# Altium schematic sheet 30to50V-24V_10A.SchDoc
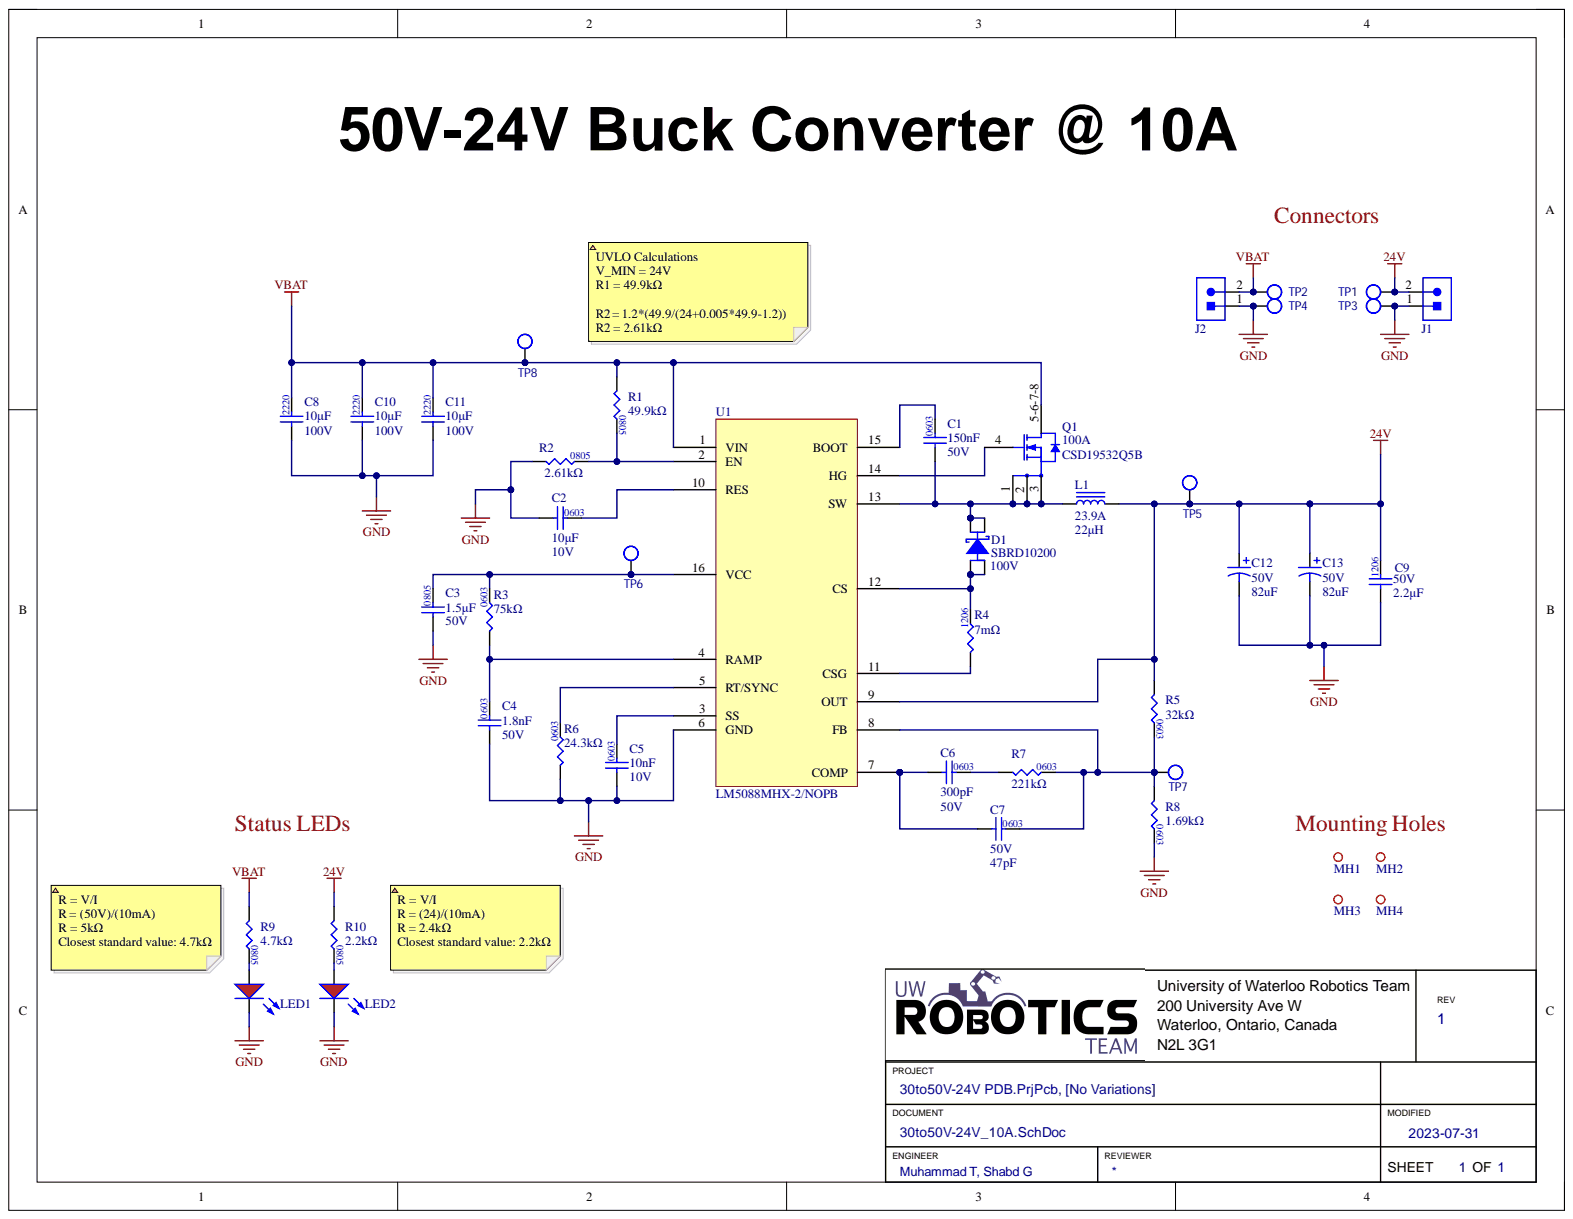
[diagram p0: 30to50V-24V_10A.SchDoc]

## Parts
C1  value 150nF  Voltage Rating: 50V  [CAP0603]  (hidden comment: CAP_150nF_50V_0603)
C2  value 10μF  Voltage Rating: 10V  [CAP0603]  (hidden comment: CAP_10uF_10V_0603)
C3  value 1.5μF  Voltage Rating: 50V  [CAP0805]  (hidden comment: CAP_1.5uF_50V_0805)
C4  value 1.8nF  Voltage Rating: 50V  [CAP0603]  (hidden comment: CAP_1.8nF_50V_0603)
C5  value 10nF  Voltage Rating: 10V  [CAP0603]  (hidden comment: CAP_10nF_25V_0603)
C6  value 300pF  Voltage Rating: 50V  [CAP0603]  (hidden comment: CAP_300pF_10V_0603)
C7  value 47pF  Package/Footprint: 0603  Voltage Rating: 50V  [CAP0603]  (hidden comment: CAP_47pF_50V_0603)
C8  value 10μF  Voltage Rating: 100V  [C5750X7S2A106M230KB]  (hidden comment: CAP_10uF_50V_2220)
C9  value 2.2μF  Voltage Rating: 50V  [CAP1206]  (hidden comment: CAP_2.2uF_50V_1206)
C10  value 10μF  Voltage Rating: 100V  [C5750X7S2A106M230KB]  (hidden comment: CAP_10uF_50V_2220)
C11  value 10μF  Voltage Rating: 100V  [C5750X7S2A106M230KB]  (hidden comment: CAP_10uF_50V_2220)
C12  Capacitance: 82uF  Voltage Rating: 50V  [RADIAL_CORNELL_D]  (hidden comment: CAP_47uF_100V_ALUM)
C13  Capacitance: 82uF  Voltage Rating: 50V  [RADIAL_CORNELL_D]  (hidden comment: CAP_47uF_100V_ALUM)
D1  Manufacturer Part Number 1: SBRD10200  Vr (Max): 100V  [D-PAK]  (hidden comment: DIO_SCHOTTKY_100V_5.5A)
J1  CON_2POS_SCREW_TERM  [1935161]  (value not drawn)
J2  CON_2POS_SCREW_TERM  [1935161]  (value not drawn)
L1  Current Rating: 23.9A  Inductance: 22μH  [74437529203220]  (hidden comment: IND_22uH_23.9A)
LED1  Package/Footprint: 0805  [LED_0805_RED]  (hidden comment: LED_RED)
LED2  Package/Footprint: 0805  [LED_0805_RED]  (hidden comment: LED_RED)
MH1  MOUNTING_HOLE_M3_GIMBAL_REV2  [MOUNTING_HOLE_3mm_GIMBAL_REV2]  (value not drawn)  (no BOM)
MH2  MOUNTING_HOLE_M3_GIMBAL_REV2  [MOUNTING_HOLE_3mm_GIMBAL_REV2]  (value not drawn)  (no BOM)
MH3  MOUNTING_HOLE_M3_GIMBAL_REV2  [MOUNTING_HOLE_3mm_GIMBAL_REV2]  (value not drawn)  (no BOM)
MH4  MOUNTING_HOLE_M3_GIMBAL_REV2  [MOUNTING_HOLE_3mm_GIMBAL_REV2]  (value not drawn)  (no BOM)
Q1  Id: 100A  Manufacturer Part Number 1: CSD19532Q5B  [SSM6K819R,LF]  (hidden comment: NMOS_100V_100A)
R1  value 49.9kΩ  Package/Footprint: 0805  [RES0805]  (hidden comment: RES_49.9kΩ_0805_1%)
R2  value 2.61kΩ  Package/Footprint: 0805  [RES0805]  (hidden comment: RES_2.61kΩ_0805_1%)
R3  value 75kΩ  [RES0603]  (hidden comment: RES_75kΩ_0603_0.5%)
R4  value 7mΩ  Package/Footprint: 1206  [RES1206]  (hidden comment: RES_0.007Ω_1206_1%)
R5  value 32kΩ  Package: 0603  [RES0603]  (hidden comment: RES_32KΩ_0603_0.1%)
R6  value 24.3kΩ  Package: 0603  [RES0603]  (hidden comment: RES_24.3kΩ_0603_1%)
R7  value 221kΩ  [RES0603]  (hidden comment: RES_221kΩ_0603_1%)
R8  value 1.69kΩ  [RES0603]  (hidden comment: RES_1.69kΩ_0603_1%)
R9  value 4.7kΩ  Package: 0805  [RES0805]  (hidden comment: RES_4.7kΩ_0805_5%)
R10  value 2.2kΩ  Package: 0805  [RES0805]  (hidden comment: RES_2.2kΩ_0805_5%)
TP1  TESTPOINT_LOOP  [TESTPOINT_LOOP]  (value not drawn)
TP2  TESTPOINT_LOOP  [TESTPOINT_LOOP]  (value not drawn)
TP3  TESTPOINT_LOOP  [TESTPOINT_LOOP]  (value not drawn)
TP4  TESTPOINT_LOOP  [TESTPOINT_LOOP]  (value not drawn)
TP5  TESTPOINT_LOOP  [TESTPOINT_LOOP]  (value not drawn)
TP6  TESTPOINT_LOOP  [TESTPOINT_LOOP]  (value not drawn)
TP7  TESTPOINT_LOOP  [TESTPOINT_LOOP]  (value not drawn)
TP8  TESTPOINT_LOOP  [TESTPOINT_LOOP]  (value not drawn)
U1  LM5088  [LM5088]

## Nets
24V: C9.2 C12.1 C13.1 J1.2 L1.2 R5.1 R10.1 TP1.1 TP5.1 U1.9(OUT)
GND: C2.1 C3.1 C4.1 C5.1 C8.1 C9.1 C10.1 C11.1 C12.2 C13.2 J1.1 J2.1 LED1.2 LED2.2 R2.1 R6.1 R8.2 TP3.1 TP4.1 U1.6(GND)
VBAT: C8.2 C10.2 C11.2 J2.2 Q1.5-6-7-8 R1.1 R9.1 TP2.1 TP8.1 U1.1(VIN)
NetC1_1: C1.1 D1.2 D1.4 L1.1 Q1.1 Q1.2 Q1.3 U1.13(SW)
NetC1_2: C1.2 U1.15(BOOT)
NetC2_2: C2.2 U1.10(RES)
NetC3_2: C3.2 R3.2 TP6.1 U1.16(VCC)
NetC4_2: C4.2 R3.1 U1.4(RAMP)
NetC5_2: C5.2 U1.3(SS)
NetC6_1: C6.1 C7.1 U1.7(COMP)
NetC6_2: C6.2 R7.1
NetC7_2: C7.2 R5.2 R7.2 R8.1 TP7.1 U1.8(FB)
NetD1_1: D1.1 D1.3 R4.2 U1.12(CS)
NetLED1_1: LED1.1 R9.2
NetLED2_1: LED2.1 R10.2
NetQ1_4: Q1.4 U1.14(HG)
NetR1_2: R1.2 R2.2 U1.2(EN)
NetR4_1: R4.1 U1.11(CSG)
NetR6_2: R6.2 U1.5(RT/SYNC)

## Library data of the parts
C1 = CAP_150nF_50V_0603: CAP CER 0.15UF 50V X7R 0603; Manufacturer 1: Yageo; Manufacturer Part Number 1: CC0603KRX7R9BB154; Component Kind: Standard; Dielectric: X7R; Supplier 1: Digi-Key; Supplier Part Number 1: 13-CC0603KRX7R9BB154CT-ND; Library Name: UWRT_Schematic_Library_2020.SchLib
C2 = CAP_10uF_10V_0603: CAP CER 10UF 10V X5R 0603; Manufacturer 1: Yageo; Manufacturer Part Number 1: CC0603MRX5R6BB106; Component Kind: Standard; Dielectric: X5R; Supplier 1: Digi-Key; Supplier Part Number 1: 311-1817-1-ND; Library Name: UWRT_Schematic_Library_2020.SchLib
C3 = CAP_1.5uF_50V_0805: CAP CER 1800PF 50V C0G/NP0 0603; Manufacturer 1: TDK Corporation; Manufacturer Part Number 1: C2012X7R1H155K125AC; Dielectric: X7R; Component Kind: Standard; Supplier 1: Digi-Key; Supplier Part Number 1: C2012X7R1H155K125AC; Library Name: UWRT_Schematic_Library_2020.SchLib
C4 = CAP_1.8nF_50V_0603: CAP CER 1800PF 50V C0G/NP0 0603; Manufacturer 1: Murata Electronics; Manufacturer Part Number 1: GRM1885C1H182JA01J; Dielectric: C0G; Component Kind: Standard; Supplier 1: Digi-Key; Supplier Part Number 1: 490-6380-1-ND; Library Name: UWRT_Schematic_Library_2020.SchLib
C5 = CAP_10nF_25V_0603: CAP CER 10000PF 25V C0G 0603; Manufacturer 1: Yageo; Manufacturer Part Number 1: C1608C0G1E103J080AA; Component Kind: Standard; Dielectric: C0G; Supplier 1: Digi-Key; Supplier Part Number 1: 445-2664-1-ND; Library Name: UWRT_Schematic_Library_2020.SchLib
C6 = CAP_300pF_10V_0603: CAP CER 300PF 50V NP0 0603; Manufacturer 1: KYOCERA AVX; Manufacturer Part Number 1: 06035A301JAT2A; Component Kind: Standard; Dielectric: NP0; Supplier 1: Digi-Key; Supplier Part Number 1: 478-10311-1-ND; Library Name: UWRT_Schematic_Library_2020.SchLib
C7 = CAP_47pF_50V_0603: CAP CER 47PF 50V C0G/NP0 0603; Manufacturer 1: Samsung Electro-Mechanics; Manufacturer Part Number 1: CL10C470JB8NNNC; Dielectric: C0G; Component Kind: Standard; Mounting Type: SMD; Supplier 1: Digi-Key; Supplier Part Number 1: 1276-1037-1-ND; Library Name: UWRT_Schematic_Library_2020.SchLib
C8 C10 C11 = CAP_10uF_50V_2220: CAP CER 10UF 100V X7S 2220; Manufacturer 1: TDK Corporation; Manufacturer Part Number 1: C5750X7S2A106K230KB; Component Kind: Standard; Dielectric: X7S; Supplier 1: Digi-Key; Supplier Part Number 1: 445-13408-1-ND; Library Name: UWRT_Schematic_Library_2020.SchLib
C9 = CAP_2.2uF_50V_1206: CAP CER 2.2UF 50V X7R 1206; Manufacturer 1: Murata Electronics; Manufacturer Part Number 1: GCM31CR71H225KA55L; Component Kind: Standard; Dielectric: X7R; Supplier 1: Digi-Key; Supplier Part Number 1: 490-4796-1-ND; Library Name: UWRT_Schematic_Library_2020.SchLib
C12 C13 = CAP_47uF_100V_ALUM: Aluminum Electrolytic Capacitor, Polarized, Aluminum (wet), 100V, 20% +Tol, 20% -Tol, 47uF, Surface Mount, 5454; Manufacturer: Nichicon; Manufacturer Part Number: UCD2A470MNQ1MS; Capacitor Type: Aluminium; Leakage Current: 47uA; Ripple Current: 250mA; Tan Delta: 0.07; Category: Aluminum Electrolytic Capacitors; Component Kind: Standard; ComponentLink1Description: https://datasheet.ciiva.com:443/pdfs/VipMasterIC/IC/NICH/NICH-S-A0003527725/NICH-S-A0003527725-1.pdf?src-supplier=IHS+Markit; ComponentLink1URL: https://datasheet.ciiva.com:443/pdfs/VipMasterIC/IC/NICH/NICH-S-A0003527725/NICH-S-A0003527725-1.pdf?src-supplier=IHS+Markit; ComponentLink2Description: https://datasheet.ciiva.com/11776/0900766b80ebdadd-11776792.pdf?src-supplier=RSComponents; ComponentLink2URL: https://datasheet.ciiva.com/11776/0900766b80ebdadd-11776792.pdf?src-supplier=RSComponents; ComponentLink3Description: https://datasheet.ciiva.com/30526/getdatasheetpartid-513218-30526979.pdf?src-supplier=Verical; ComponentLink3URL: https://datasheet.ciiva.com/30526/getdatasheetpartid-513218-30526979.pdf?src-supplier=Verical; ComponentLink4Description: https://datasheet.ciiva.com/16389/e-cd-16389182.pdf?src-supplier=Future+Electronics; ComponentLink4URL: https://datasheet.ciiva.com/16389/e-cd-16389182.pdf?src-supplier=Future+Electronics; ComponentLink5Description: https://datasheet.ciiva.com/17523/e-cd-17523974.pdf?src-supplier=Avnet+Europe; ComponentLink5URL: https://datasheet.ciiva.com/17523/e-cd-17523974.pdf?src-supplier=Avnet+Europe; ComponentLink6Description: https://datasheet.ciiva.com/6326/e-cd-6326630.pdf?src-supplier=Digi-Key; ComponentLink6URL: https://datasheet.ciiva.com/6326/e-cd-6326630.pdf?src-supplier=Digi-Key; ComponentLink7Description: https://datasheet.ciiva.com/6326/e-cd-6326632.pdf?src-supplier=Digi-Key; ComponentLink7URL: https://datasheet.ciiva.com/6326/e-cd-6326632.pdf?src-supplier=Digi-Key; ComponentLink8Description: https://datasheet.ciiva.com/6326/e-cd-6326634.pdf?src-supplier=Digi-Key; ComponentLink8URL: https://datasheet.ciiva.com/6326/e-cd-6326634.pdf?src-supplier=Digi-Key; Dielectric Material: Aluminium; Height: 13.5mm; JESD-609 Code: e3; Length: 14.6mm; Max Operating Temperature: 105°C; Min Operating Temperature: -55°C; Mount: Surface Mount; Negative Tolerance: 20%; Number of Pins: 2; Package Shape: Cylindrical; Package Style: SMTMeter; Packaging: Tape and Reel; Polarity: Polarized; Positive Tolerance: 20%; Pricing 1: 1=2.15000, 10=1.69800, 50=1.47140, 100=1.27330 (USD); Rated DC Voltage (URdc): 100V; REACH SVHC: Yes; RoHS: Yes; RoHS Compliant: Yes; Size Code: 7575; Stock 1: 515; Supplier 1: Digi-Key; Supplier Part Number 1: 493-6201-1-ND; Terminal Finish: Copper; Termination: Flat; Width: 13.6mm; Library Name: UWRT_Schematic_Library_2020.SchLib
D1 = DIO_SCHOTTKY_200V_10A: DIODE SCHOTTKY 200V 10A DPAK; Manufacturer 1: SMC Diode Solutions; Package/Footprint: TO-252-3, DPak (2 Leads + Tab), SC-63; Component Kind: Standard; Mounting Type: SMD; Supplier 1: Digi-Key; Supplier Part Number 1: 1655-SBRD10200CT-ND; Library Name: UWRT_Schematic_Library_2020.SchLib
J1 J2 = CON_2POS_SCREW_TERM: TERM BLK 2POS SIDE ENTRY 5MM; Manufacturer 1: Phoenix Contact; Package/Footprint: SMD; Component Kind: Standard; Manufacturer Part Number 1: 1935161; Mounting Type: TH; Supplier 1: Digi-Key; Supplier Part Number 1: 277-1667-ND; Library Name: UWRT_Schematic_Library_2020.SchLib
L1 = IND_22uH_23.9A: FIXED IND 22UH 30A 2.4 MOHM SMD; Manufacturer 1: Würth Elektronik; DC Resistance (DCR): 4.9mR; Inductance Tolerance: 20%; Component Kind: Standard; Manufacturer Part Number 1: 74437529203220; Mounting Type: SMD; Saturation Current: 23.9A; Supplier 1: Digi-Key; Supplier Part Number 1: 732-11711-ND; Library Name: UWRT_Schematic_Library_2020.SchLib
LED1 LED2 = LED_RED: LED RED DIFFUSED 0805 SMD; Manufacturer 1: OSRAM; Manufacturer Part Number 1: LS R976-NR-1; Forward Voltage Drop: 2V; Component Kind: Standard; Mounting Type: SMD; Supplier 1: Digi-Key; Supplier Part Number 1: 475-1278-1-ND; Library Name: UWRT_Schematic_Library_2020.SchLib
MH1-MH4 = MOUNTING_HOLE_M3_GIMBAL_REV2: MOUNTING_HOLE_M3 Gimbal Rev2; Library Name: UWRT_Schematic_Library_2020.SchLib
Q1 = NMOS_100V_100A: MOSFET N-CH 100V 100A 8VSON; Manufacturer 1: Texas Instruments; Package/Footprint: 8-VSON-CLIP; Vgs (Max): ±20V; Component Kind: Standard; Mounting Type: SMD; Supplier 1: Digi-Key; Supplier Part Number 1: 296-37478-1-ND; Library Name: UWRT_Schematic_Library_2020.SchLib
R1 = RES_49.9kΩ_0805_1%: RES 49.9K OHM 1% 1/8W 0805; Manufacturer 1: Vishay Dale; Manufacturer Part Number 1: TNPW080549K9BEEN; Component Kind: Standard; LCSC Part #: C17719; Mounting Type: SMD; Supplier 1: Digi-Key; Supplier Part Number 1: 541-3802-1-ND; Library Name: UWRT_Schematic_Library_2020.SchLib
R2 = RES_2.61kΩ_0805_1%: RES 2.61K OHM 1% 1/8W 0805; Manufacturer 1: Vishay Dale; Manufacturer Part Number 1: RC0805FR-072K61L; Component Kind: Standard; Mounting Type: SMD; Supplier 1: Digi-Key; Supplier Part Number 1: 311-2.61KCRCT-ND; Library Name: UWRT_Schematic_Library_2020.SchLib
R3 = RES_75kΩ_0603_0.5%: RES 75K OHM 0.5% 1/10W 0603; Manufacturer 1: Yageo; Manufacturer Part Number 1: RT0603DRD0775KL; Component Kind: Standard; Supplier 1: Digi-Key; Supplier Part Number 1: 311-75KDCT-ND; Library Name: UWRT_Schematic_Library_2020.SchLib
R4 = RES_0.007Ω_1206_1%: RES 0.007 OHM 1% 1W 1206; Manufacturer 1: Rohm Semiconductor; Manufacturer Part Number 1: PMR18EZPFU7L00; Component Kind: Standard; Mounting Type: SMD; Supplier 1: Digi-Key; Supplier Part Number 1: RHM.007ALCT-ND; Library Name: UWRT_Schematic_Library_2020.SchLib
R5 = RES_32KΩ_0603_0.1%: RES SMD 32K OHM 0.1% 1/10W 0603; Manufacturer 1: Yageo; Manufacturer Part Number 1: RT0603BRD0732KL; Component Kind: Standard; Mounting Type: SMD; Supplier 1: Digi-Key; Supplier Part Number 1: 13-RT0603BRD0732KLCT-ND; Library Name: UWRT_Schematic_Library_2020.SchLib
R6 = RES_24.3kΩ_0603_1%: RES 24.3K OHM 1% 1/10W 0603; Manufacturer 1: YAGEO; Manufacturer Part Number 1: RC0603FR-0724K3L; Power: 0.1W; Component Kind: Standard; Supplier 1: Digi-Key; Supplier Part Number 1: 311-24.3KHRCT-ND; Tolerance: 1%; Library Name: UWRT_Schematic_Library_2020.SchLib
R7 = RES_221kΩ_0603_1%: RES 221K OHM 1% 1/10W 0603; Manufacturer 1: Vishay Dale; Manufacturer Part Number 1: RC0603FR-07221KL; Component Kind: Standard; Supplier 1: Digi-Key; Supplier Part Number 1: 311-221KHRCT-ND; Library Name: UWRT_Schematic_Library_2020.SchLib
R8 = RES_1.69kΩ_0603_1%: RES 1.69K OHM 1% 1/10W 0603; Manufacturer 1: Yageo; Manufacturer Part Number 1: RC0603FR-071K69L; Component Kind: Standard; Supplier 1: Digi-Key; Supplier Part Number 1: 311-1.69KHRCT-ND; Library Name: UWRT_Schematic_Library_2020.SchLib
R9 = RES_4.7kΩ_0805_5%: RES 4.7K OHM 5% 1/8W 0805; Manufacturer 1: Yageo; Manufacturer Part Number 1: RC0805JR-074K7L; Component Kind: Standard; LCSC Part #: C17673; Mounting Type: SMD; Supplier 1: Digi-Key; Supplier Part Number 1: 311-4.7KARCT-ND; Library Name: UWRT_Schematic_Library_2020.SchLib
R10 = RES_2.2kΩ_0805_5%: RES 2.2K OHM 5% 1/8W 0805; Manufacturer 1: Vishay Dale; Manufacturer Part Number 1: CRCW08052K20JNEAC; Component Kind: Standard; Supplier 1: Digi-Key; Supplier Part Number 1: 541-4169-1-ND; Tolerance: 5%; Library Name: UWRT_Schematic_Library_2020.SchLib
TP1-TP8 = TESTPOINT_LOOP: TESTPOINT THRUHOLE; Manufacturer 1: Keystone Electronics; Manufacturer Part Number 1: 5002; Package/Footprint: TH; Component Kind: Standard; Mounting Type: TH; Supplier 1: Digi-Key; Supplier Part Number 1: 36-5002-ND; Library Name: UWRT_Schematic_Library_2020.SchLib
U1 = LM5088MHX-2/NOPB: IC REG CTRLR BUCK 16HTSSOP; Manufacturer 1: Texas Instruments; Supply Voltage: 4.5V - 75V; Switching Frequency: 50kHz - 1MHz; Component Kind: Standard; Manufacturer Part Number 1: LM5088MHX-2/NOPB; Package: 16-HTSSOP; Supplier 1: Digi-Key; Supplier Part Number 1: 296-35302-1-ND; Library Name: UWRT_Schematic_Library_2020.SchLib
